# Altium schematic sheet MIX.SchDoc(MIX)
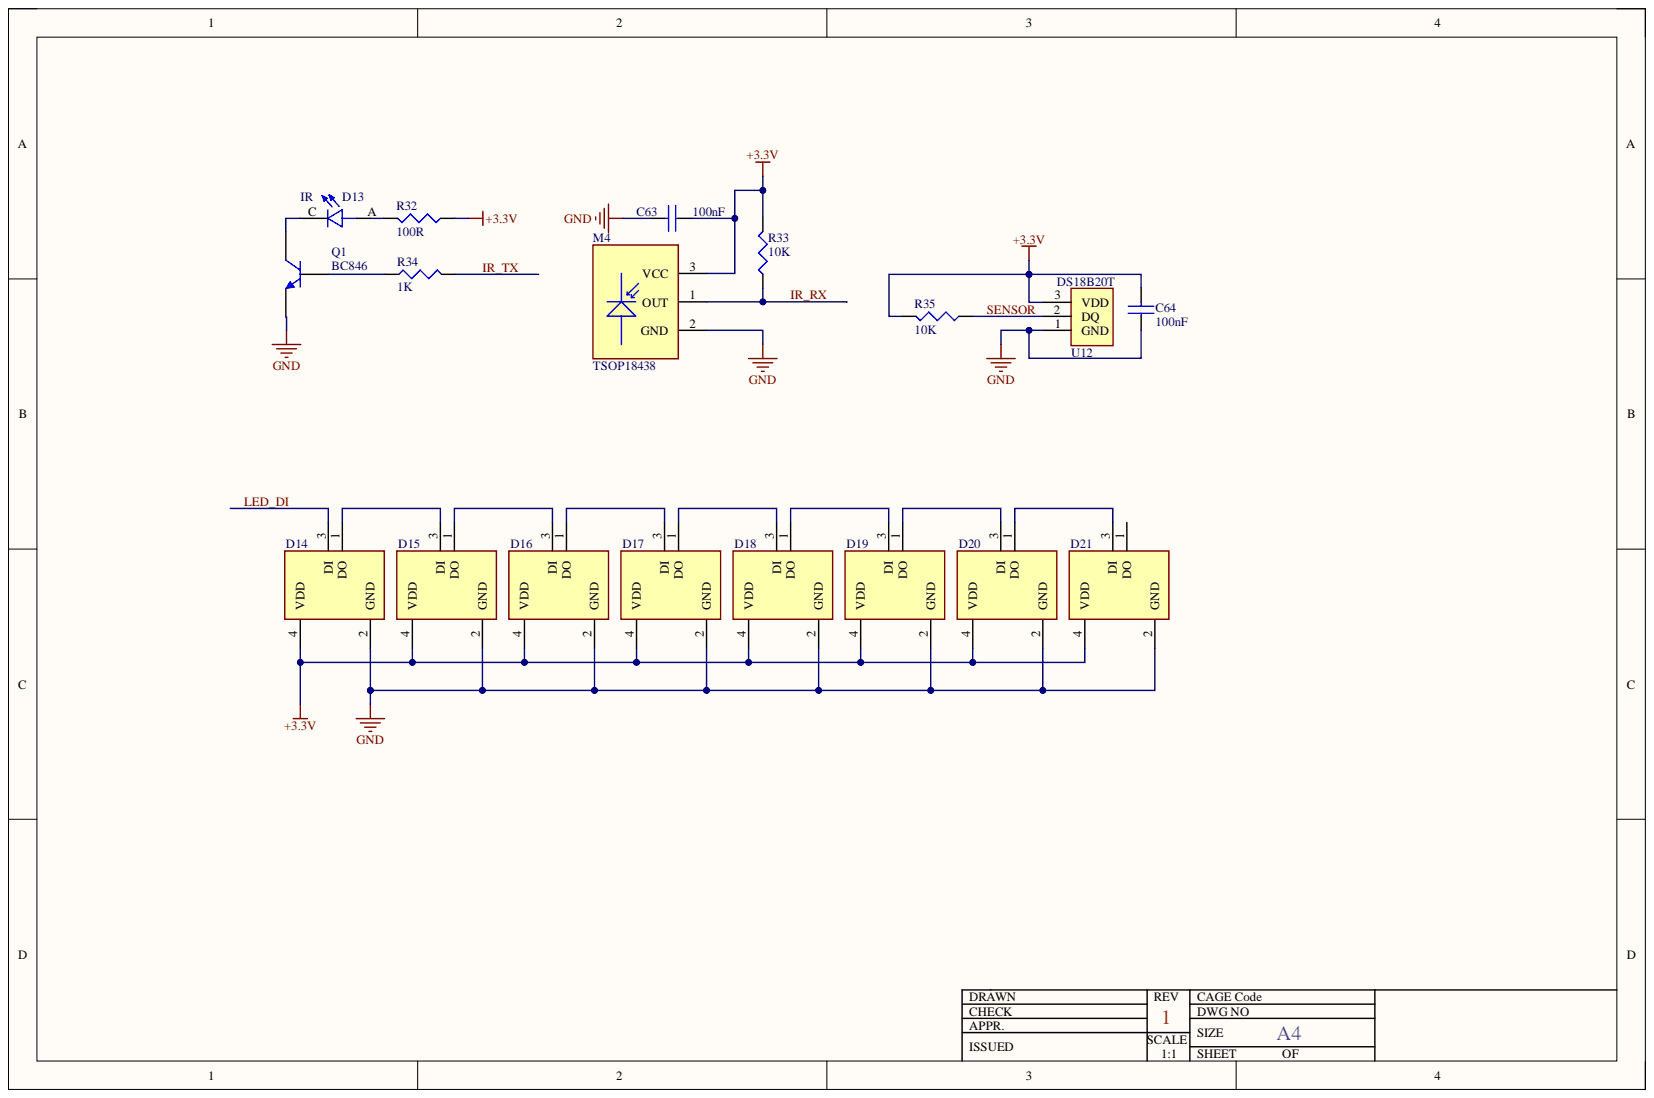
[diagram p0: MIX.SchDoc]

## Parts
C63  value 100nF  [0805 SMD Ceramic Capacitor]  (hidden comment: C0805C104)
C64  value 100nF  [0805 SMD Ceramic Capacitor]  (hidden comment: C0805C104)
D13  TSHG6200  [LEDRD254W55D595H900]  (value not drawn)
D14  WS2812C-2020  [LED_WS2812C-2020]  (value not drawn)
D15  WS2812C-2020  [LED_WS2812C-2020]  (value not drawn)
D16  WS2812C-2020  [LED_WS2812C-2020]  (value not drawn)
D17  WS2812C-2020  [LED_WS2812C-2020]  (value not drawn)
D18  WS2812C-2020  [LED_WS2812C-2020]  (value not drawn)
D19  WS2812C-2020  [LED_WS2812C-2020]  (value not drawn)
D20  WS2812C-2020  [LED_WS2812C-2020]  (value not drawn)
D21  WS2812C-2020  [LED_WS2812C-2020]  (value not drawn)
M4  TSOP18438  [TSOP18438]
Q1  BC846  [SOT23]
R32  value 100R  [0805 Resistor]  (hidden comment: 0805_100R%1)
R33  value 10K  [0805 Resistor]  (hidden comment: 0805_10K)
R34  value 1K  [0805 Resistor]  (hidden comment: 0805_1K)
R35  value 10K  [0805 Resistor]  (hidden comment: 0805_10K)
U12  DS18B20  value DS18B20T  [TO92]

## Nets
+3.3V: C63.1 C64.2 D14.4(VDD) D15.4(VDD) D16.4(VDD) D17.4(VDD) D18.4(VDD) D19.4(VDD) D20.4(VDD) D21.4(VDD) M4.3 R32.1 R33.2 R35.2 U12.3
GND: C63.2 C64.1 D14.2(GND) D15.2(GND) D16.2(GND) D17.2(GND) D18.2(GND) D19.2(GND) D20.2(GND) D21.2(GND) M4.2 Q1.2 U12.1
IR_RX: M4.1 R33.1
IR_TX: R34.2
LED_DI: D14.3(DI)
SENSOR: R35.1 U12.2
NetD13_A: D13.A R32.2
NetD13_C: D13.C Q1.3
NetD14_1: D14.1(DO) D15.3(DI)
NetD15_1: D15.1(DO) D16.3(DI)
NetD16_1: D16.1(DO) D17.3(DI)
NetD17_1: D17.1(DO) D18.3(DI)
NetD18_1: D18.1(DO) D19.3(DI)
NetD19_1: D19.1(DO) D20.3(DI)
NetD20_1: D20.1(DO) D21.3(DI)
NetQ1_1: Q1.1 R34.1

Not connected: D21 1(DO)

## Library data of the parts
C63 C64 = C0805C104: Manufacturer: KEMET; Manufacturer Code: C0805C104J5RACTU; Voltage: 50V; Component Kind: Standard; Farnell Code: 1414663; Library Name: Capacitors.SchLib; Package: 0805; Unit Price: 0,06 €
D13 = TSHG6200: Infrared Emitter 850nm 1800mW/sr Circular Top Mount 2-Pin T-1 3/4; Manufacturer: Vishay; Component Kind: Standard; Digikey Code: 751-1212-ND; Library Name: TSHG6200.IntLib; Manufacturer Code: TSHG6200; MP: TSHG6200; Price: 1.56 $; Value: TSHG6200
D14-D21 = WS2812C-2020: SMD,2x2mm RGB LEDs(Built-in IC) ROHS; Manufacturer: Worldsemi; Manufacturer Code: WS2812C-2020-V1; Component Kind: Standard; Library Name: WS2812C-2020.SchLib; MOQ: 5; Price: 0.069 $; Value: WS2812C
M4 = TSOP18438: IR Remote Receiver 38KHz 35m 3-Pin; Manufacturer: Vishay; Component Kind: Standard; Digikey Code: TSOP18438-ND; Library Name: TSOP18438.IntLib; Manufacturer Code: TSOP18438; Unit Price: 0.78 $; Value: TSOP18438
Q1 = BC846: Manufacturer: NXP Semiconductors; Voltage: 65V; Component Kind: Standard; Farnell Code: 1081227; Library Name: BC846.SchLib; Manufacturer Code: BC846; Unit Price: 0,049 €; Value: BC846
R32 = 0805_100R%1: Manufacturer: MULTICOMP; Manufacturer Code: MC 0.1W 0805 1% 100R; Tolerance: ±1%; Component Kind: Standard; Farnell Code: 9332375; Library Name: Resistors.SchLib; Unit Price: 0,03 €
R33 R35 = 0805_10K: Manufacturer: MULTICOMP; Manufacturer Code: MC 0.1W 0805 1% 10K; Tolerance: ±1%; Component Kind: Standard; Farnell Code: 9332391; Library Name: Resistors.SchLib; Unit Price: 0,03 €
R34 = 0805_1K: Manufacturer: MULTICOMP; Manufacturer Code: MC01W080511K; Tolerance: ±1%; Component Kind: Standard; Farnell Code: 9332383; Library Name: Resistors.SchLib; Unit Price: 0,03 €
U12 = DS18B20: Temperature Control and Regulation, Temperature Sensing/Measurement, Industrial Control, Sensors (Acceleration, Press...; Manufacturer: XBLW; Manufacturer Code: DS18B20T(XBLW); Component Kind: Standard; Library Name: DS18B20.IntLib; Package: TO-226-3 Maxim; Unit Price: 0.2565 $
